# Altium schematic sheet 3_wm8978.SchDoc(3_wm8978)
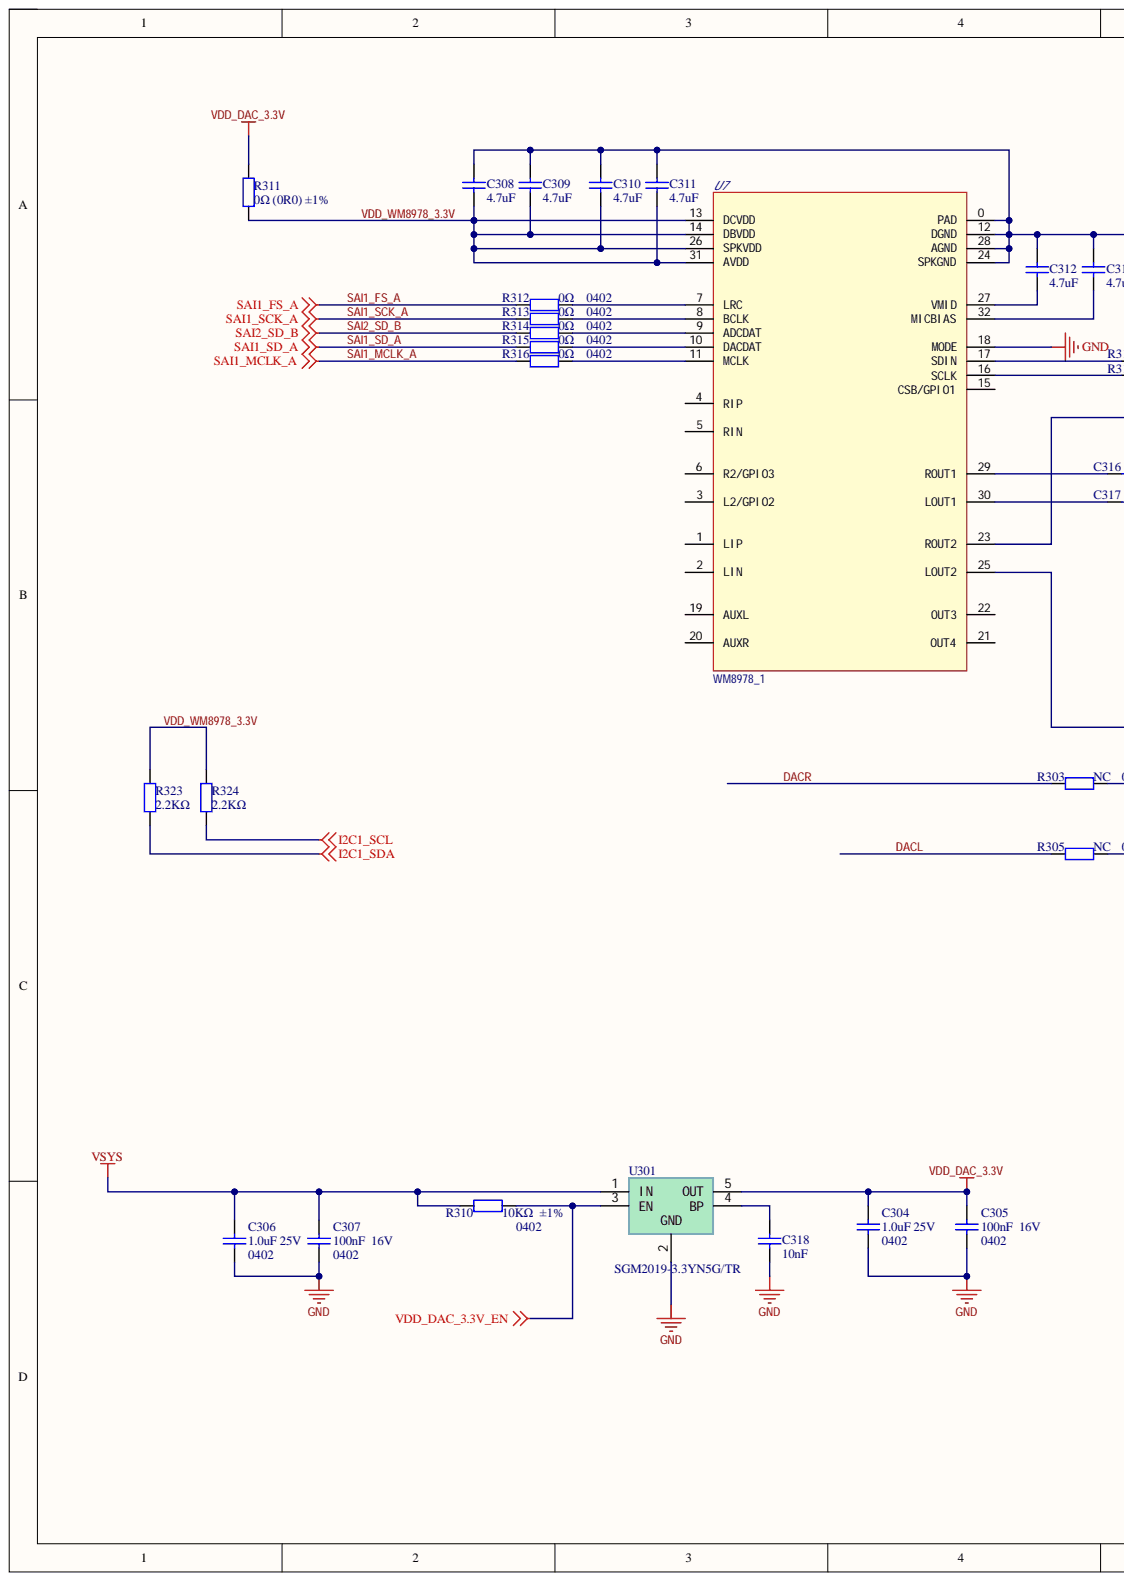
[diagram p0: 3_wm8978.SchDoc, left]
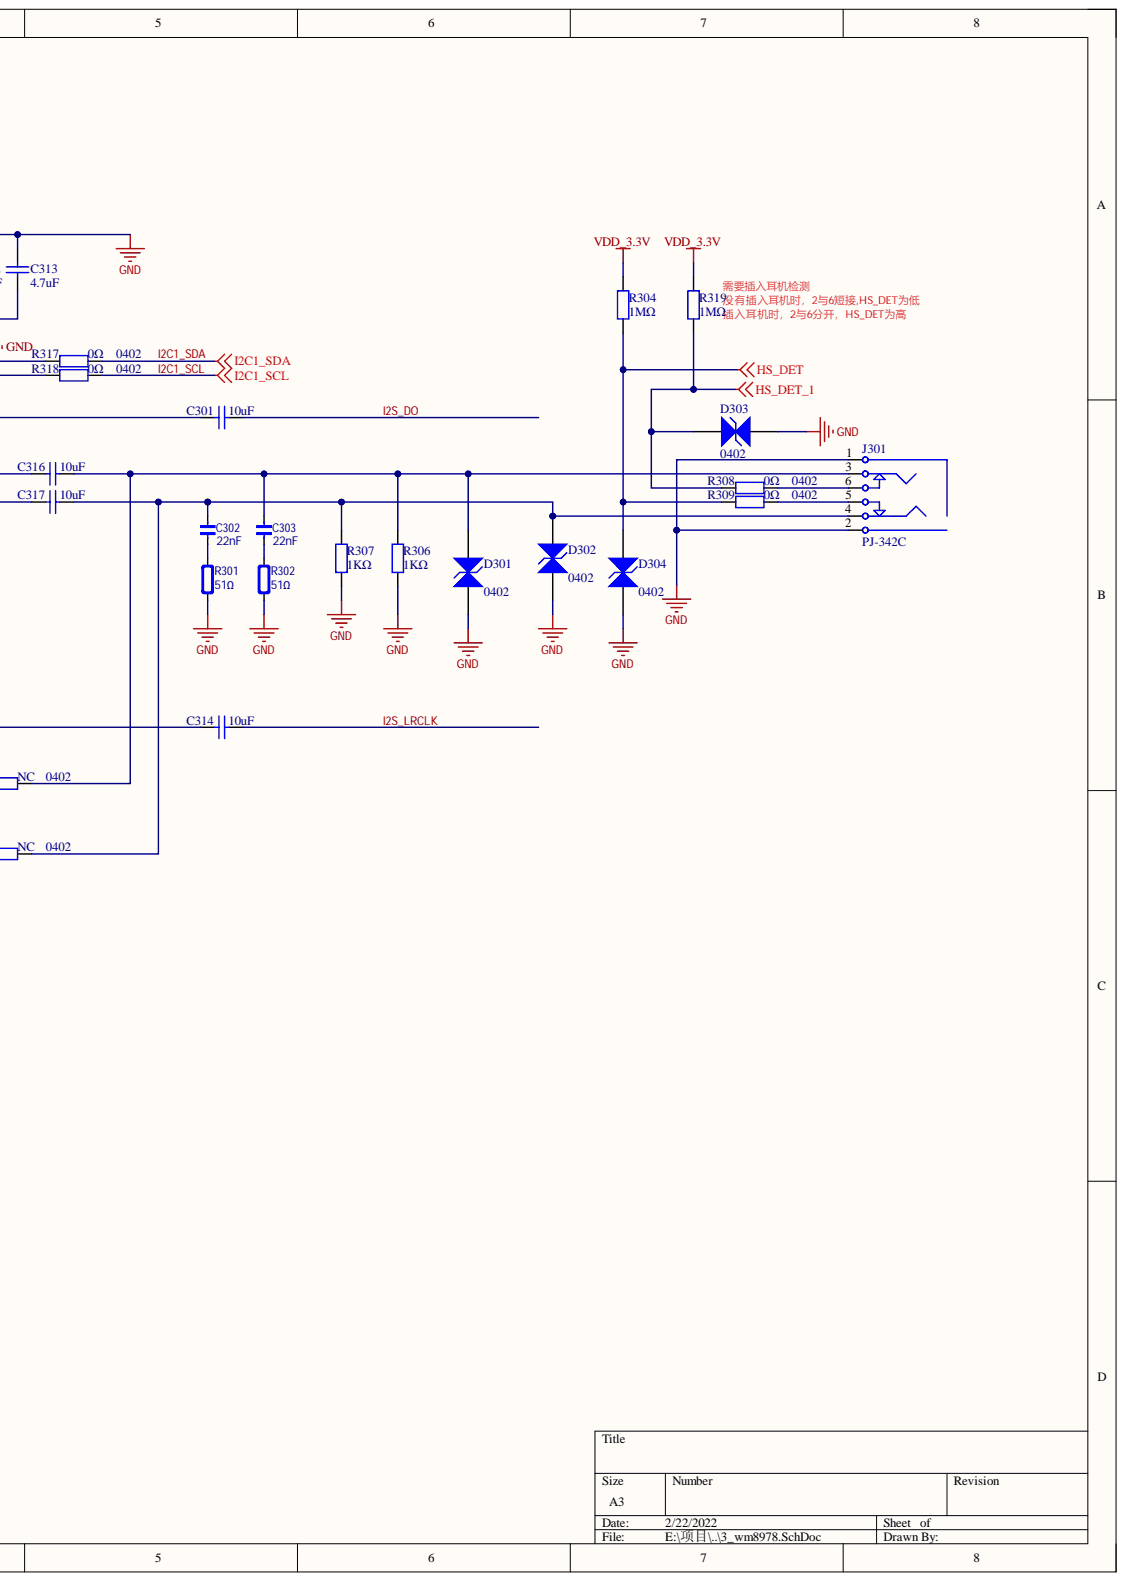
[diagram p1: 3_wm8978.SchDoc, right]

## Parts
C301  10uF  [0402_C]
C302  22nF  [0402_C]
C303  22nF  [0402_C]
C304  1.0uF 25V  Package: 0402  [0402_C]
C305  100nF  16V  Package: 0402  [0402_C]
C306  1.0uF 25V  Package: 0402  [0402_C]
C307  100nF  16V  Package: 0402  [0402_C]
C308  4.7uF  [0402_C]
C309  4.7uF  [0402_C]
C310  4.7uF  [0402_C]
C311  4.7uF  [0402_C]
C312  4.7uF  [0402_C]
C313  4.7uF  [0402_C]
C314  10uF  [0402_C]
C316  10uF  [0402_C]
C317  10uF  [0402_C]
C318  10nF  [0402_C]
D301  Package: 0402  [DFN1006]  (hidden comment: RCLAMP0521P-N)
D302  Package: 0402  [DFN1006]  (hidden comment: RCLAMP0521P-N)
D303  Package: 0402  [DFN1006]  (hidden comment: RCLAMP0521P-N)
D304  Package: 0402  [DFN1006]  (hidden comment: RCLAMP0521P-N)
J301  PJ-342C  [PJ-342C]
R301  51Ω  [0402_R]
R302  51Ω  [0402_R]
R303  NC  Package: 0402  [0402_R]
R304  1MΩ  [0402_R]
R305  NC  Package: 0402  [0402_R]
R306  1KΩ  [0402_R]
R307  1KΩ  [0402_R]
R308  0Ω  Package: 0402  [0402_R]
R309  0Ω  Package: 0402  [0402_R]
R310  10KΩ  ±1%  Package: 0402  [0402_R]
R311  0Ω (0R0) ±1%  [0402_R]
R312  0Ω  Package: 0402  [0402_R]
R313  0Ω  Package: 0402  [0402_R]
R314  0Ω  Package: 0402  [0402_R]
R315  0Ω  Package: 0402  [0402_R]
R316  0Ω  Package: 0402  [0402_R]
R317  0Ω  Package: 0402  [0402_R]
R318  0Ω  Package: 0402  [0402_R]
R319  1MΩ  [0402_R]
R323  2.2KΩ  [0402_R]
R324  2.2KΩ  [0402_R]
U7  WM8978_1  [QFN-32_5x5x05P]
U301  SGM2019-3.3YN5G/TR  [SGM2019-3.3YN5G/TR]

## Nets
GND: C304.1 C305.1 C306.1 C307.1 C308.2 C309.2 C310.2 C311.2 C312.2 C313.2 C318.1 D301.1 D302.1 D303.1 D304.1 J301.1 J301.2 R301.1 R302.1 R306.1 R307.1 U7.0(PAD) U7.12(DGND) U7.18(MODE) U7.24(SPKGND) U7.28(AGND) U301.2
HS_DET: D304.2 R304.1 R309.2
HS_DET_1: D303.2 R308.2 R319.1
VDD_3.3V: R304.2 R319.2
VDD_DAC_3.3V: C304.2 C305.2 R311.2 U301.5(OUT)
VDD_DAC_3.3V_EN: R310.1 U301.3(EN)
VSYS: C306.2 C307.2 R310.2 U301.1(IN)
DACL: R305.1
DACR: R303.1
I2C1_SCL: R318.1 R324.1
I2C1_SDA: R317.1 R323.1
I2S_DO: C301.2
I2S_LRCLK: C314.2
SAI1_FS_A: R312.2
SAI1_MCLK_A: R316.2
SAI1_SCK_A: R313.2
SAI1_SD_A: R315.2
SAI2_SD_B: R314.2
VDD_WM8978_3.3V: C308.1 C309.1 C310.1 C311.1 R311.1 R323.2 R324.2 U7.13(DCVDD) U7.14(DBVDD) U7.26(SPKVDD) U7.31(AVDD)
NetC301_1: C301.1 U7.23(ROUT2)
NetC302_1: C302.1 R301.2
NetC302_2: C302.2 C317.2 D302.2 J301.4 R305.2 R307.2
NetC303_1: C303.1 R302.2
NetC303_2: C303.2 C316.2 D301.2 J301.3 R303.2 R306.2
NetC312_1: C312.1 U7.27(VMID)
NetC313_1: C313.1 U7.32(MICBIAS)
NetC314_1: C314.1 U7.25(LOUT2)
NetC316_1: C316.1 U7.29(ROUT1)
NetC317_1: C317.1 U7.30(LOUT1)
NetC318_2: C318.2 U301.4(BP)
NetJ301_5: J301.5 R309.1
NetJ301_6: J301.6 R308.1
NetR312_1: R312.1 U7.7(LRC)
NetR313_1: R313.1 U7.8(BCLK)
NetR314_1: R314.1 U7.9(ADCDAT)
NetR315_1: R315.1 U7.10(DACDAT)
NetR316_1: R316.1 U7.11(MCLK)
NetR317_2: R317.2 U7.17(SDIN)
NetR318_2: R318.2 U7.16(SCLK)

Not connected: U7 1(LIP) 2(LIN) 3(L2/GPIO2) 4(RIP) 5(RIN) 6(R2/GPIO3) 15(CSB/GPIO1) 19(AUXL) 20(AUXR) 21(OUT4) 22(OUT3)

## Library data of the parts
C301 C314 C316 C317 = 0402 10uF (106) 20% 6.3V: 贴片电容; Component Kind: Standard; ComponentLink1Description: 供应商链接; ComponentLink1URL: http://www.szlcsc.com/product/details_16204.html; Library Name: Miscellaneous Devices LC.IntLib; Package: 0402; Supplier: LC; SuppliersPartNumber: C15525
C302 C303 = Cap_0402: Capacitor; Note: ±10%; Specification: X7R, ±10%, 16V; Type: 0402; Component Kind: Standard; Library Name: Integrated_Library.IntLib
C304 C306 = 0402 1.0uF (105) 10% 25V: 贴片电容; Component Kind: Standard; ComponentLink1Description: 供应商链接; ComponentLink1URL: http://www.szlcsc.com/product/details_53938.html; Library Name: Miscellaneous Devices LC.IntLib; Supplier: LC; SuppliersPartNumber: C52923
C305 C307 = 0402 100nF (104) 10% 16V: 贴片电容; Component Kind: Standard; ComponentLink1Description: 供应商链接; ComponentLink1URL: http://www.szlcsc.com/product/details_1877.html; Library Name: Miscellaneous Devices LC.IntLib; Supplier: LC; SuppliersPartNumber: C1525
C308-C313 = 0402 4.7uF (475) 20% 10V: 贴片电容; Component Kind: Standard; ComponentLink1Description: 供应商链接; ComponentLink1URL: http://www.szlcsc.com/product/details_24469.html; Library Name: Miscellaneous Devices LC.IntLib; Package: 0402; Supplier: LC; SuppliersPartNumber: C23733
C318 = 0402 10nF (103) 10% 50V: 贴片电容; Component Kind: Standard; ComponentLink1Description: 供应商链接; ComponentLink1URL: http://www.szlcsc.com/product/details_15869.html; Library Name: Miscellaneous Devices LC.IntLib; Package: 0402; Supplier: LC; SuppliersPartNumber: C15195
D301-D304 = TVS_RCLAMP0521P-N: TVS  BORN伯恩半导体  RCLAMP0521P-N; Component Kind: Standard; ComponentLink1Description: 供应商链接; ComponentLink1URL: http://www.szlcsc.com/product/details_79530.html; Library Name: AD_LIB.SchLib; Supplier: LC; SuppliersPartNumber: C316043
J301 = PJ-342C: 品　　牌： XKB Connectivity(中国星坤)  厂家型号： PJ-342C  商品编号： C319101  封装： SMD; Component Kind: Standard; Library Name: AD_LIB.SchLib
R301 R302 = Res_0402: Resistor; Note: ±1%; Value: ?K; Component Kind: Standard; Library Name: Integrated_Library.IntLib; Specification: ±1%; Type: 0402
R303 R305 = NC_0402贴片电阻: 贴片电阻; Component Kind: Standard; ComponentLink1Description: 供应商链接; ComponentLink1URL: http://www.szlcsc.com/product/details_26498.html; Library Name: AD_LIB.IntLib
R304 R319 = 0402 1MΩ (1004) 1%: 贴片电阻; Component Kind: Standard; ComponentLink1Description: 供应商链接; ComponentLink1URL: http://www.szlcsc.com/product/details_26826.html; Library Name: Miscellaneous Devices LC.IntLib; Package: 0402; Supplier: LC; SuppliersPartNumber: C26083
R306 R307 = 0402 1KΩ (1001) 1%: 贴片电阻; Component Kind: Standard; ComponentLink1Description: 供应商链接; ComponentLink1URL: http://www.szlcsc.com/product/details_12256.html; Library Name: Miscellaneous Devices LC.IntLib; Package: 0402; Supplier: LC; SuppliersPartNumber: C11702
R308 R309 R311-R318 = 0402 0Ω (0R0) 1%: 贴片电阻; Component Kind: Standard; ComponentLink1Description: 供应商链接; ComponentLink1URL: http://www.szlcsc.com/product/details_17853.html; Library Name: Miscellaneous Devices LC.IntLib; Supplier: LC; SuppliersPartNumber: C17168
  R311: Package: 0402
R310 = 0402 10KΩ (1002) 1%: 贴片电阻; Component Kind: Standard; ComponentLink1Description: 供应商链接; ComponentLink1URL: http://www.szlcsc.com/product/details_26487.html; Library Name: Miscellaneous Devices LC.IntLib; Supplier: LC; SuppliersPartNumber: C25744
R323 R324 = 0402 2.2KΩ (2201) 1%: 贴片电阻; Component Kind: Standard; ComponentLink1Description: 供应商链接; ComponentLink1URL: http://www.szlcsc.com/product/details_26622.html; Library Name: Miscellaneous Devices LC.IntLib; Package: 0402; Supplier: LC; SuppliersPartNumber: C25879
U7 = WM8978: 音频编解码器; Component Kind: Standard; Library Name: StdLib_TW.IntLib
U301 = SGM2019-3.3YN5G/TR: 品　　牌： SGMICRO(圣邦微)  厂家型号： SGM2019-3.3YN5G/TR  商品编号： C23613  封装： SOT-23-5; Component Kind: Standard; Library Name: AD_LIB.SchLib
